# Altium schematic sheet E22-XXXM30S.SchDoc
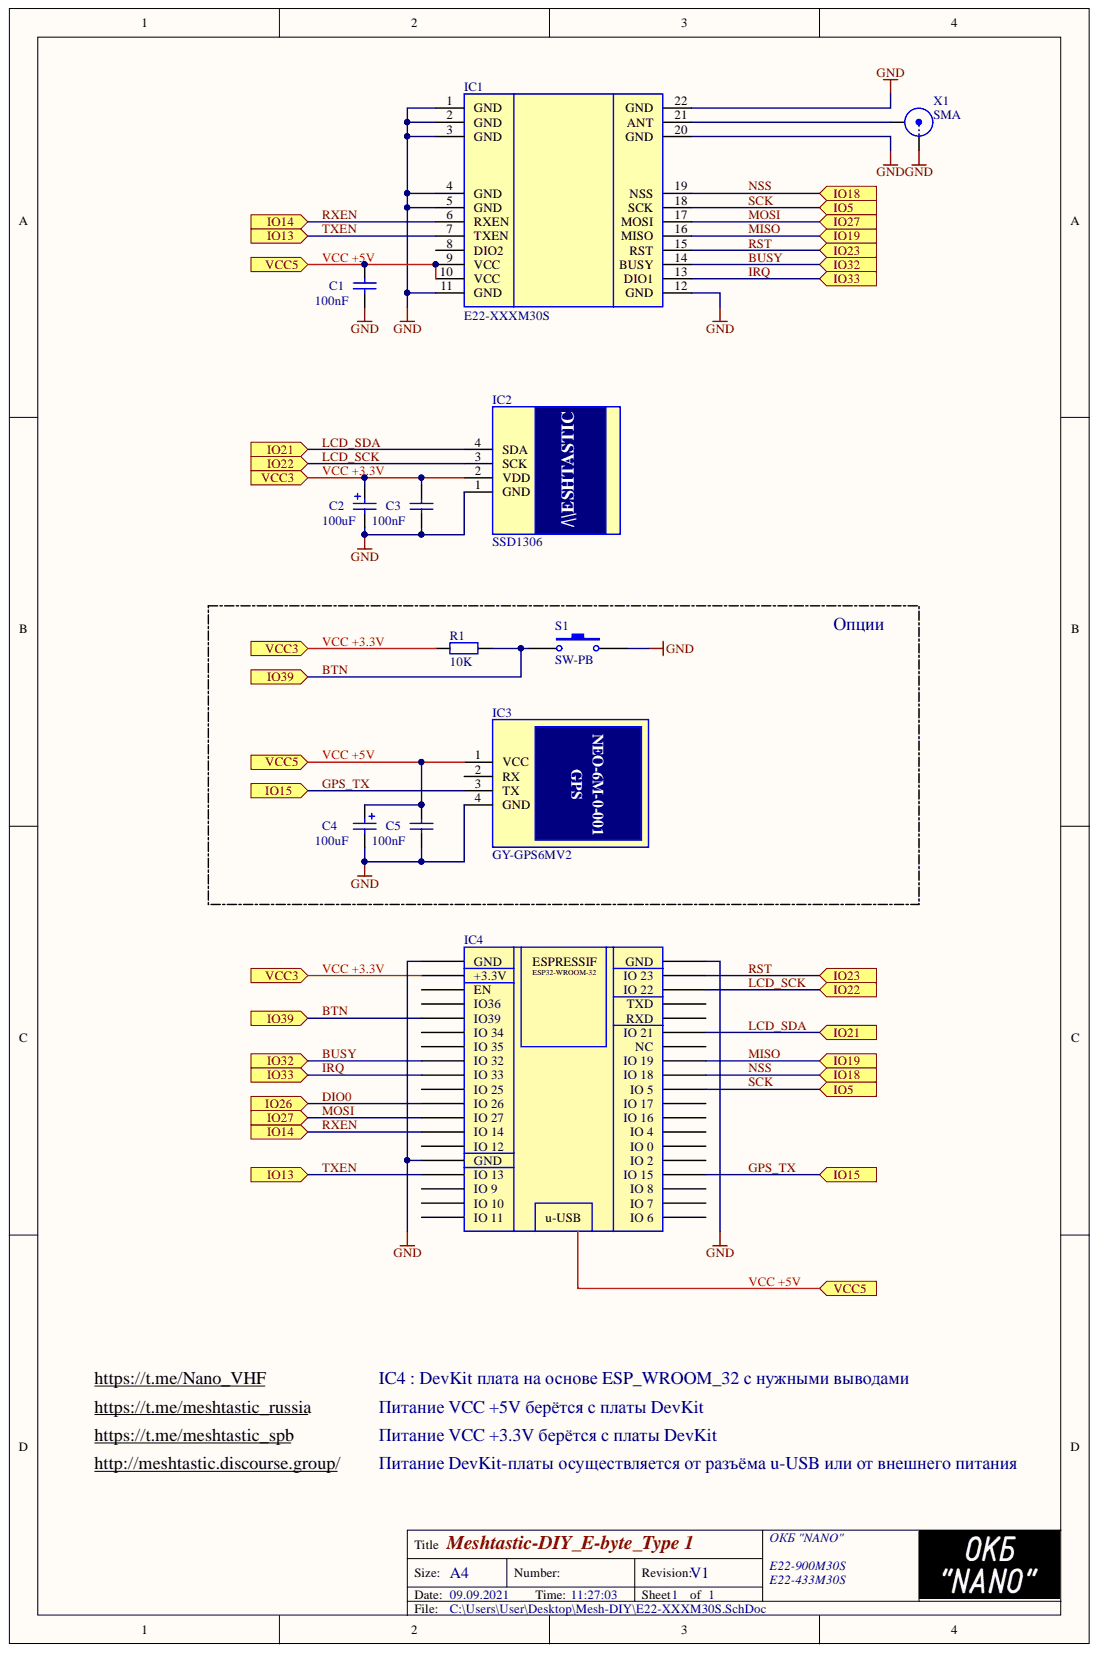
[diagram p0: E22-XXXM30S.SchDoc]

## Parts
C1  value 100nF  [RAD-0.3]  (hidden comment: Cap)
C2  value 100uF  [POLAR0.8]  (hidden comment: Cap Pol2)
C3  value 100nF  [RAD-0.3]  (hidden comment: Cap)
C4  value 100uF  [POLAR0.8]  (hidden comment: Cap Pol2)
C5  value 100nF  [RAD-0.3]  (hidden comment: Cap)
IC1  E22-XXXM30S  [RFM98W433S2]
IC2  SSD1306  [RFM98W433S2]
IC3  GY-GPS6MV2  [RFM98W433S2]
IC4  ESP_wroom_32  [ESP32S]  (value not drawn)
R1  10k  [AXIAL-0.4]
S1  SW-PB  [SPST-2]
X1  SMA  [BNC_RA CON]

## Ports
IO5, IO13, IO14, IO15, IO18, IO19, IO21, IO22, IO23, IO26, IO27, IO32, IO33, IO39, VCC3, VCC5

## Nets
GND: C1.1 C2.2 C3.1 C4.2 C5.1 IC1.1(GND) IC1.2(GND) IC1.3(GND) IC1.4(GND) IC1.5(GND) IC1.11(GND) IC1.12(GND) IC1.20(GND) IC1.22(GND) IC2.1(GND) IC3.4(GND) IC4.14(GND) IC4.19(GND) IC4.38(GND) S1.1 X1.1
BTN: IC4.4(IO39) R1.2 S1.2 ; port IO39
BUSY: IC1.14(BUSY) IC4.7(IO_32) ; port IO32
DIO0: IC4.10(IO_26) ; port IO26
GPS_TX: IC3.3(TX) IC4.23(IO_15) ; port IO15
IRQ: IC1.13(DIO1) IC4.8(IO_33) ; port IO33
LCD_SCK: IC2.3(SCK) IC4.36(IO_22) ; port IO22
LCD_SDA: IC2.4(SDA) IC4.33(IO_21) ; port IO21
MISO: IC1.16(MISO) IC4.31(IO_19) ; port IO19
MOSI: IC1.17(MOSI) IC4.11(IO_27) ; port IO27
NSS: IC1.19(NSS) IC4.30(IO_18) ; port IO18
RST: IC1.15(RST) IC4.37(IO_23) ; port IO23
RXEN: IC1.6(RXEN) IC4.12(IO_14) ; port IO14
SCK: IC1.18(SCK) IC4.29(IO_5) ; port IO5
TXEN: IC1.7(TXEN) IC4.15(IO_13) ; port IO13
VCC +3.3V: C2.1 C3.2 IC2.2(VDD) IC4.1(+3.3V) R1.1 ; port VCC3
VCC +5V: C1.2 C4.1 C5.2 IC1.9(VCC) IC1.10(VCC) IC3.1(VCC) ; port VCC5
NetIC1_21: IC1.21(ANT) X1.2

Not connected: IC1 8(DIO2); IC3 2(RX); IC4 2(EN) 3(IO36) 5(IO_34) 6(IO_35) 9(IO_25) 13(IO_12) 16(IO_9) 17(IO_10) 18(IO_11) 20(IO_6) 21(IO_7) 22(IO_8) 24(IO_2) 25(IO_0) 26(IO_4) 27(IO_16) 28(IO_17) 32(NC) 34(RXD) 35(TXD)

## Library data of the parts
C1 C3 C5 = Cap: Capacitor; Component Kind: Standard; LatestRevisionDate: 17-Jul-2002; LatestRevisionNote: Re-released for DXP Platform.; Library Name: Miscellaneous Devices.IntLib; PackageDescription: Radial Cap, Thru-Hole; 2 Leads; 0.3 in Pin Spacing; PackageReference: RAD-0.3; Published: 8-Jun-2000; Signal Integrity: Cap; Simulation: CAP; Publisher: Altium Limited
C2 C4 = Cap Pol2: Polarized Capacitor (Axial); Component Kind: Standard; LatestRevisionDate: 17-Jul-2002; LatestRevisionNote: Re-released for DXP Platform.; Library Name: Miscellaneous Devices.IntLib; PackageDescription: Polarized Capacitor; 2 Leads; PackageReference: POLAR0.8; Published: 8-Jun-2000; Signal Integrity: Cap Pol; Simulation: CAP; Publisher: Altium Limited
IC1 = E22-900M30S: E22-433M30S; Component Kind: Standard; Library Name: ESP32S.SchLib
IC2 = SSD1306: OLED LCD; Manufacturer_Name: RF SOLUTIONS; Manufacturer_Part_Number: RFM98W-433S2; Component Kind: Standard; Datasheet Link: http://www.hoperf.com/upload/rf/RFM95_96_97_98W.pdf; Height: 1.8mm; Library Name: ESP32S.SchLib; Mouser Part Number: 223-RFM98W-433S2; Mouser Price/Stock: https://www.mouser.co.uk/ProductDetail/RF-Solutions/RFM98W-433S2?qs=OlC7AqGiEDlY9molZxTrLQ%3D%3D
IC3 = GPS: Manufacturer_Name: RF SOLUTIONS; Manufacturer_Part_Number: RFM98W-433S2; Component Kind: Standard; Datasheet Link: http://www.hoperf.com/upload/rf/RFM95_96_97_98W.pdf; Description: GY-GPS6MV2; Height: 1.8mm; Library Name: ESP32S.SchLib; Mouser Part Number: 223-RFM98W-433S2; Mouser Price/Stock: https://www.mouser.co.uk/ProductDetail/RF-Solutions/RFM98W-433S2?qs=OlC7AqGiEDlY9molZxTrLQ%3D%3D
IC4 = ESP_wroom_32: Esp-32S; Component Kind: Standard; Datasheet: https://espressif.com/sites/default/files/documentation/esp_wroom_32_datasheet_en.pdf; Library Name: ESP32S.SchLib
R1 = Res2: Resistor; Component Kind: Standard; LatestRevisionDate: 17-Jul-2002; LatestRevisionNote: Re-released for DXP Platform.; Library Name: Miscellaneous Devices.IntLib; PackageDescription: Axial Device, Thru-Hole; 2 Leads; 0.4 in Pin Spacing; PackageReference: AXIAL-0.4; Published: 8-Jun-2000; Signal Integrity: Res; Simulation: RESISTOR; Value: 10K; Publisher: Altium Limited
S1 = SW-PB: Switch; Component Kind: Standard; LatestRevisionDate: 17-Jul-2002; LatestRevisionNote: Re-released for DXP Platform.; Library Name: Miscellaneous Devices.IntLib; PackageDescription: Switch; 2 Leads; PackageReference: SPST-2; Published: 24-Mar-1999; Publisher: Altium Limited
X1 = BNC: BNC Elbow Connector; Component Kind: Standard; LatestRevisionDate: 17-Jul-2002; LatestRevisionNote: Re-released for DXP Platform.; Library Name: Miscellaneous Connectors.IntLib; Signal Integrity: Connector; Publisher: Altium Limited
